# Altium schematic sheet THYRISTOR POWER REGULATOR.SchDoc
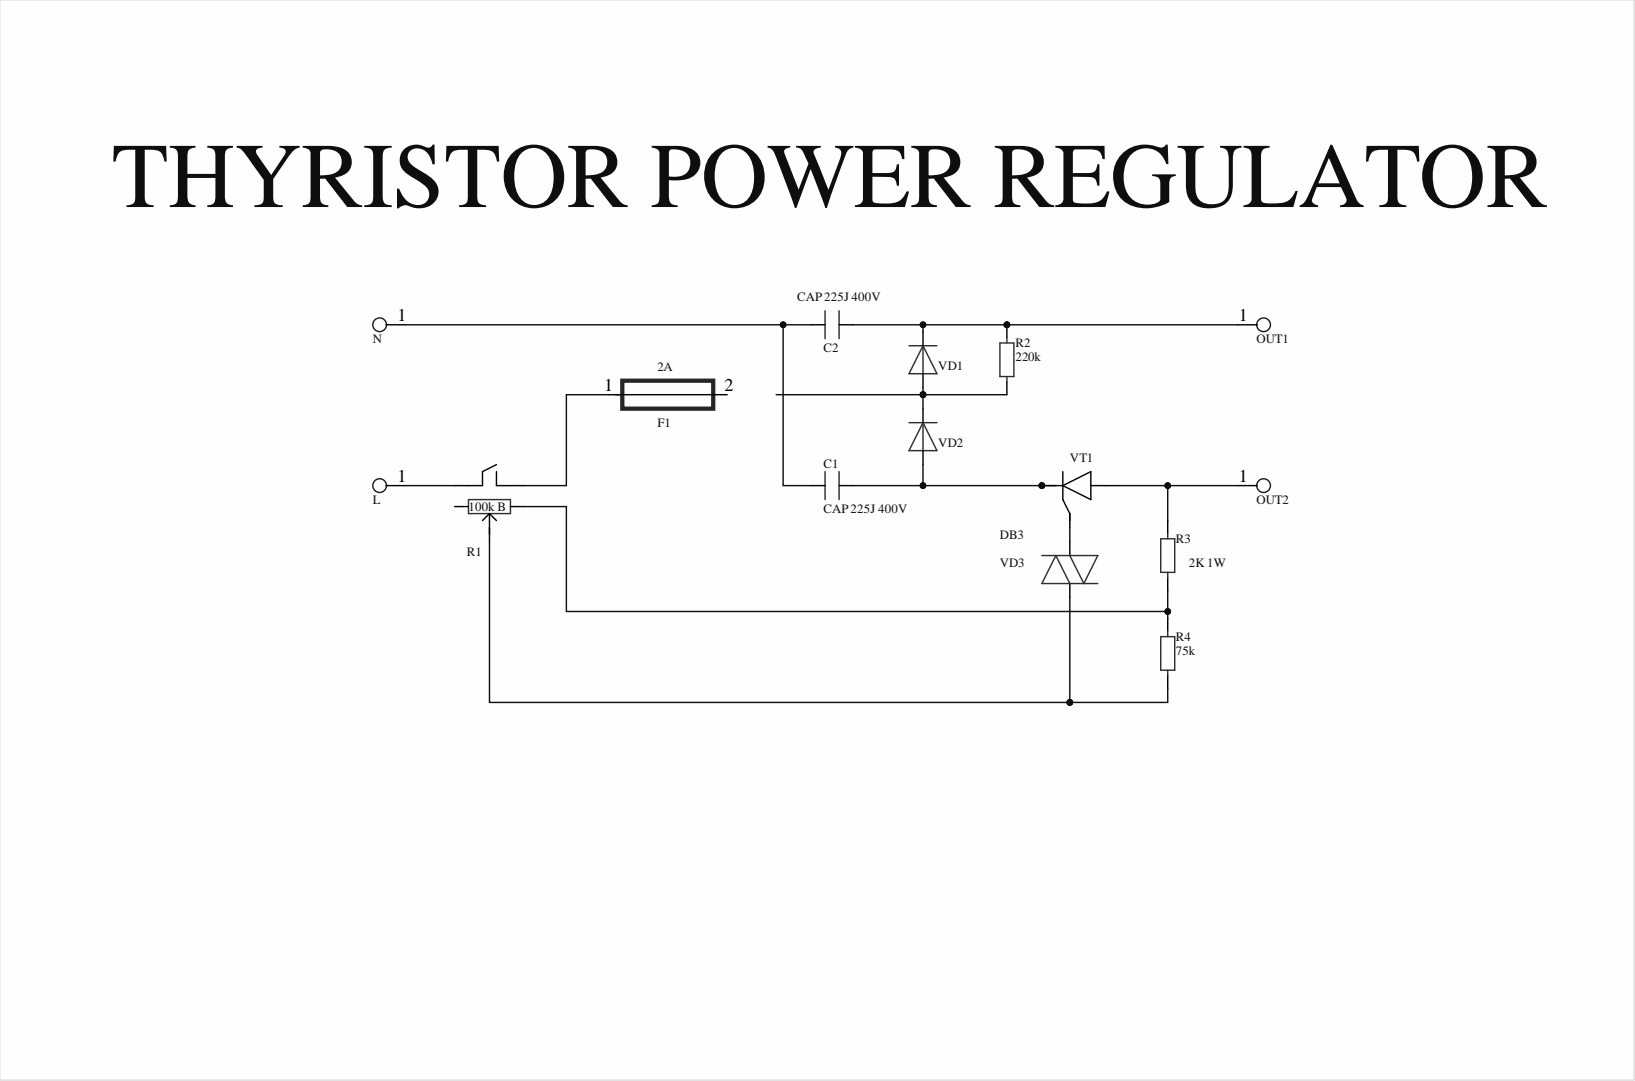
[diagram p0: THYRISTOR POWER REGULATOR.SchDoc]

## Parts
C1  CAP 225J 400V  [CAP 225J 400V]
C2  CAP 225J 400V  [CAP 225J 400V]
F1  2A  [BMS 2S]
L  Test_Point_PCB  [Test_Point]  (value not drawn)
N  Test_Point_PCB  [Test_Point]  (value not drawn)
OUT1  Test_Point_PCB  [Test_Point]  (value not drawn)
OUT2  Test_Point_PCB  [Test_Point]  (value not drawn)
R1  100k B  [RES_VAR]
R2  220k  [RES-1206]
R3  2K 1W  [RES-2512]
R4  75k  [RES-1206]
VD1  DIODE  [DO-214AA]  (value not drawn)
VD2  DIODE  [DO-214AA]  (value not drawn)
VD3  DB3  [DB3]
VT1  TYN412  [TO-220-3]  (value not drawn)

## Nets
NetC1_1: C1.1 VD2.2 VT1.1
NetC1_2: C1.2 C2.2 N.1
NetC2_1: C2.1 OUT1.1 R2.1 VD1.1
NetF1_1: F1.1 R1.4
NetL_1: L.1 R1.5
NetOUT2_1: OUT2.1 R3.2 VT1.2
NetR1_1: R1.1 R3.1 R4.1
NetR1_2: R1.2 R4.2 VD3.2
NetR2_2: R2.2 VD1.2 VD2.1
NetVD3_1: VD3.1 VT1.3

Not connected: F1 2; R1 3

## Library data of the parts
F1 = F?: Component Kind: Standard; Library Name: fuse.IntLib
L N OUT1 OUT2 = TP_PCB?: Test_Point; Component Kind: Standard; Library Name: TEST_POINT.IntLib
R1 = RES_VAR: Component Kind: Standard; Library Name: RES_VAR.IntLib
R2-R4 = RES-CONST: Component Kind: Standard; Library Name: PASSIVE_COMPONENTS.IntLib
VD1 VD2 = B340B-13-F: Diode Schottky 40 V 3A Surface Mount SMB; Manufacturer: Diodes Incorporated; Database Table Name: $Diodes; ID: D-00025; In Stock: 0; Component Kind: Standard; ComponentLink1Description: Database URL; ComponentLink1URL: http://el.udtech.dev/component/1956/detail; Library Name: Database_Libs2.DbLib; Part Number: B340B-13-F; Value: B340B-13-F
VD3 = DIODE: Component Kind: Standard; Library Name: DB3.IntLib
C1 C2 = CAP 225J 400V: Component Kind: Standard; Library Name: CAP 225J 400V.IntLib
VT1 = TYN412: Component Kind: Standard; Library Name: TYN412.IntLib
